# Altium schematic sheet DAQ.SchDoc
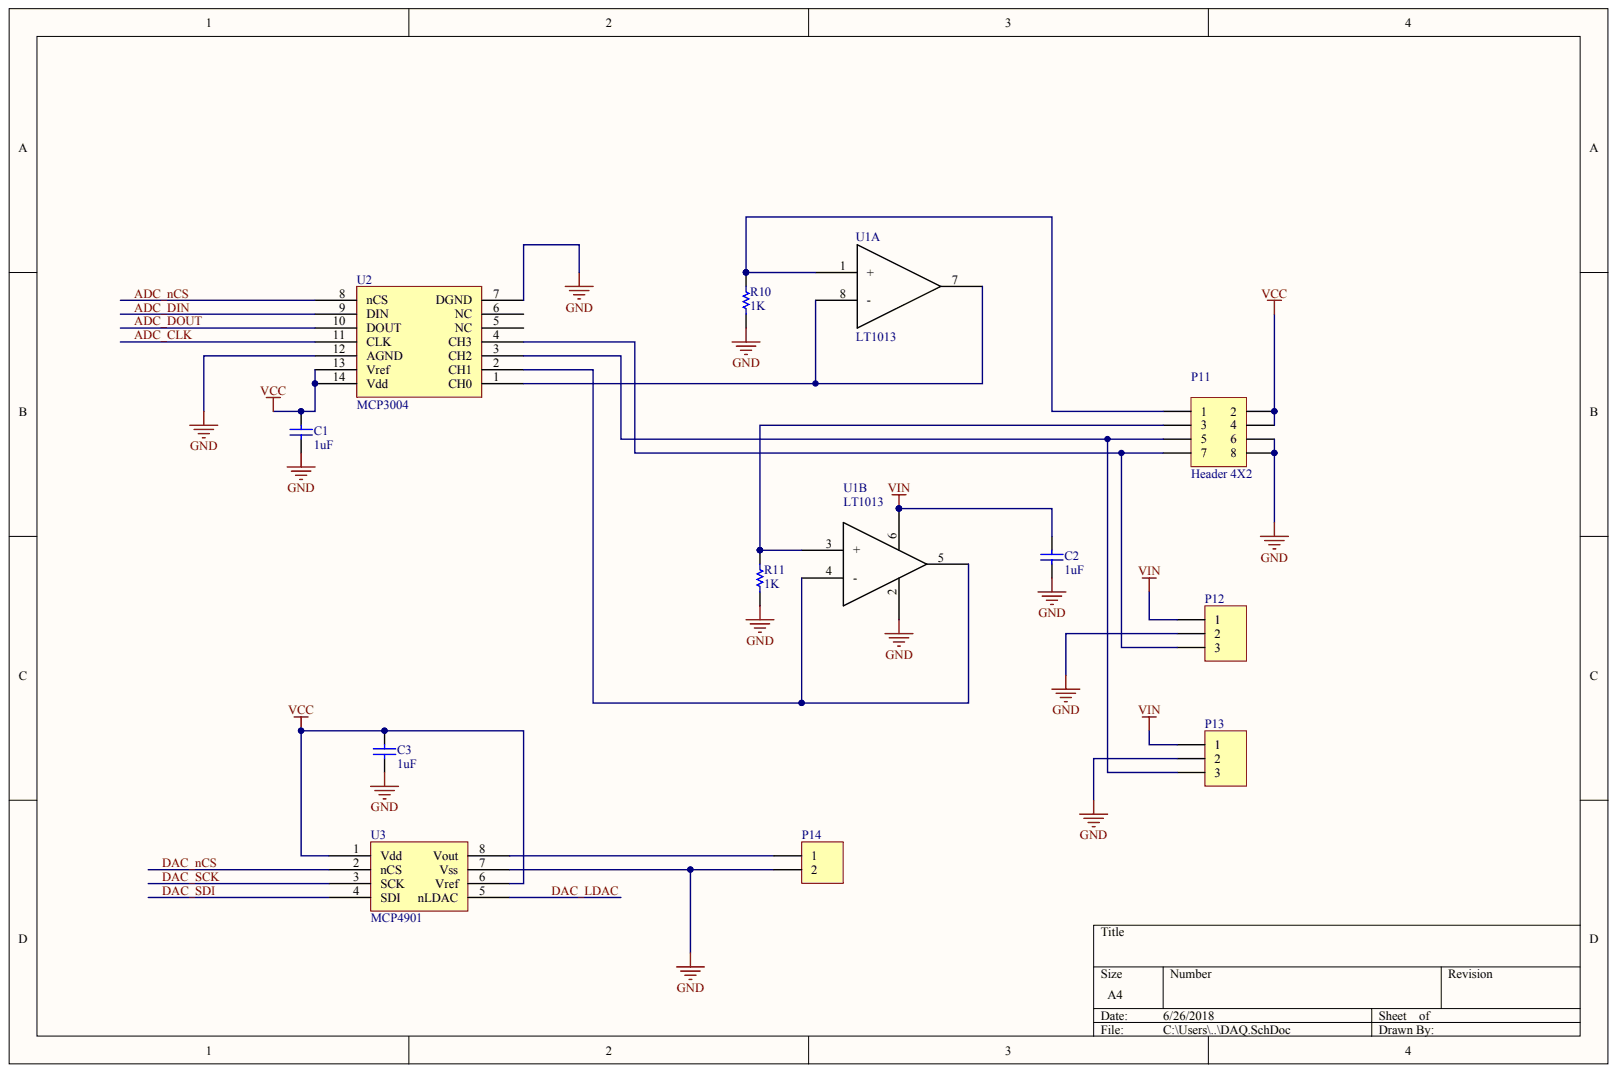
[diagram p0: DAQ.SchDoc]

## Parts
C1  value 1uF  (hidden comment: Cap)
C2  value 1uF  (hidden comment: Cap)
C3  value 1uF  (hidden comment: Cap)
P11  Header 4X2
P12  Header 3  (value not drawn)
P13  Header 3  (value not drawn)
P14  Header 2  (value not drawn)
R10  value 1K  (hidden comment: Res1)
R11  value 1K  (hidden comment: Res1)
U1  LT1013  (parts A,B)
U2  MCP3004
U3  MCP4901

## Nets
GND: C1.1 C2.1 C3.1 P11.6 P11.8 P12.2 P13.2 P14.2 R10.1 R11.1 U1.2 U2.7(DGND) U2.12(AGND) U3.7(Vss)
VCC: C1.2 C3.2 P11.2 P11.4 U2.13(Vref) U2.14(Vdd) U3.1(Vdd) U3.6(Vref)
VIN: C2.2 P12.1 P13.1 U1.6
ADC_CLK: U2.11(CLK)
ADC_DIN: U2.9(DIN)
ADC_DOUT: U2.10(DOUT)
ADC_NCS: U2.8(nCS)
DAC_LDAC: U3.5(nLDAC)
DAC_NCS: U3.2(nCS)
DAC_SCK: U3.3(SCK)
DAC_SDI: U3.4(SDI)
NetP11_1: P11.1 R10.2 U1.1(+)
NetP11_3: P11.3 R11.2 U1.3(+)
NetP11_5: P11.5 P13.3 U2.3(CH2)
NetP11_7: P11.7 P12.3 U2.4(CH3)
NetP14_1: P14.1 U3.8(Vout)
NetU1_4: U1.4(-) U1.5 U2.2(CH1)
NetU1_7: U1.7 U1.8(-) U2.1(CH0)

Not connected: U2 5(NC) 6(NC)

## Library data of the parts
C1-C3 P11-P14 R10 R11 U1-U3 = (no symbol name)
  C1-C3: Published: 8-Jun-2000; LatestRevisionDate: 17-Jul-2002; LatestRevisionNote: Re-released for DXP Platform.; PackageReference: RAD-0.3; PackageDescription: Radial Cap, Thru-Hole; 2 Leads; 0.3 in Pin Spacing
  P11-P14: LatestRevisionDate: 17-Jul-2002; LatestRevisionNote: Re-released for DXP Platform.
  R10 R11: Published: 8-Jun-2000; LatestRevisionDate: 17-Jul-2002; LatestRevisionNote: Re-released for DXP Platform.; PackageReference: AXIAL-0.3; PackageDescription: Axial Device, Thru-Hole; 2 Leads; 0.3 in Pin Spacing
  U1: Digikey PN: 296-14615-1-ND
  U2: Digikey PN: MCP3004-I/P-ND
  U3: Digikey PN: MCP4901-E/P-ND
  C1-C3 P11-P14 R10 R11: Publisher: Altium Limited
